# Altium schematic sheet 4  - Latching.SchDoc("4  - Latching")
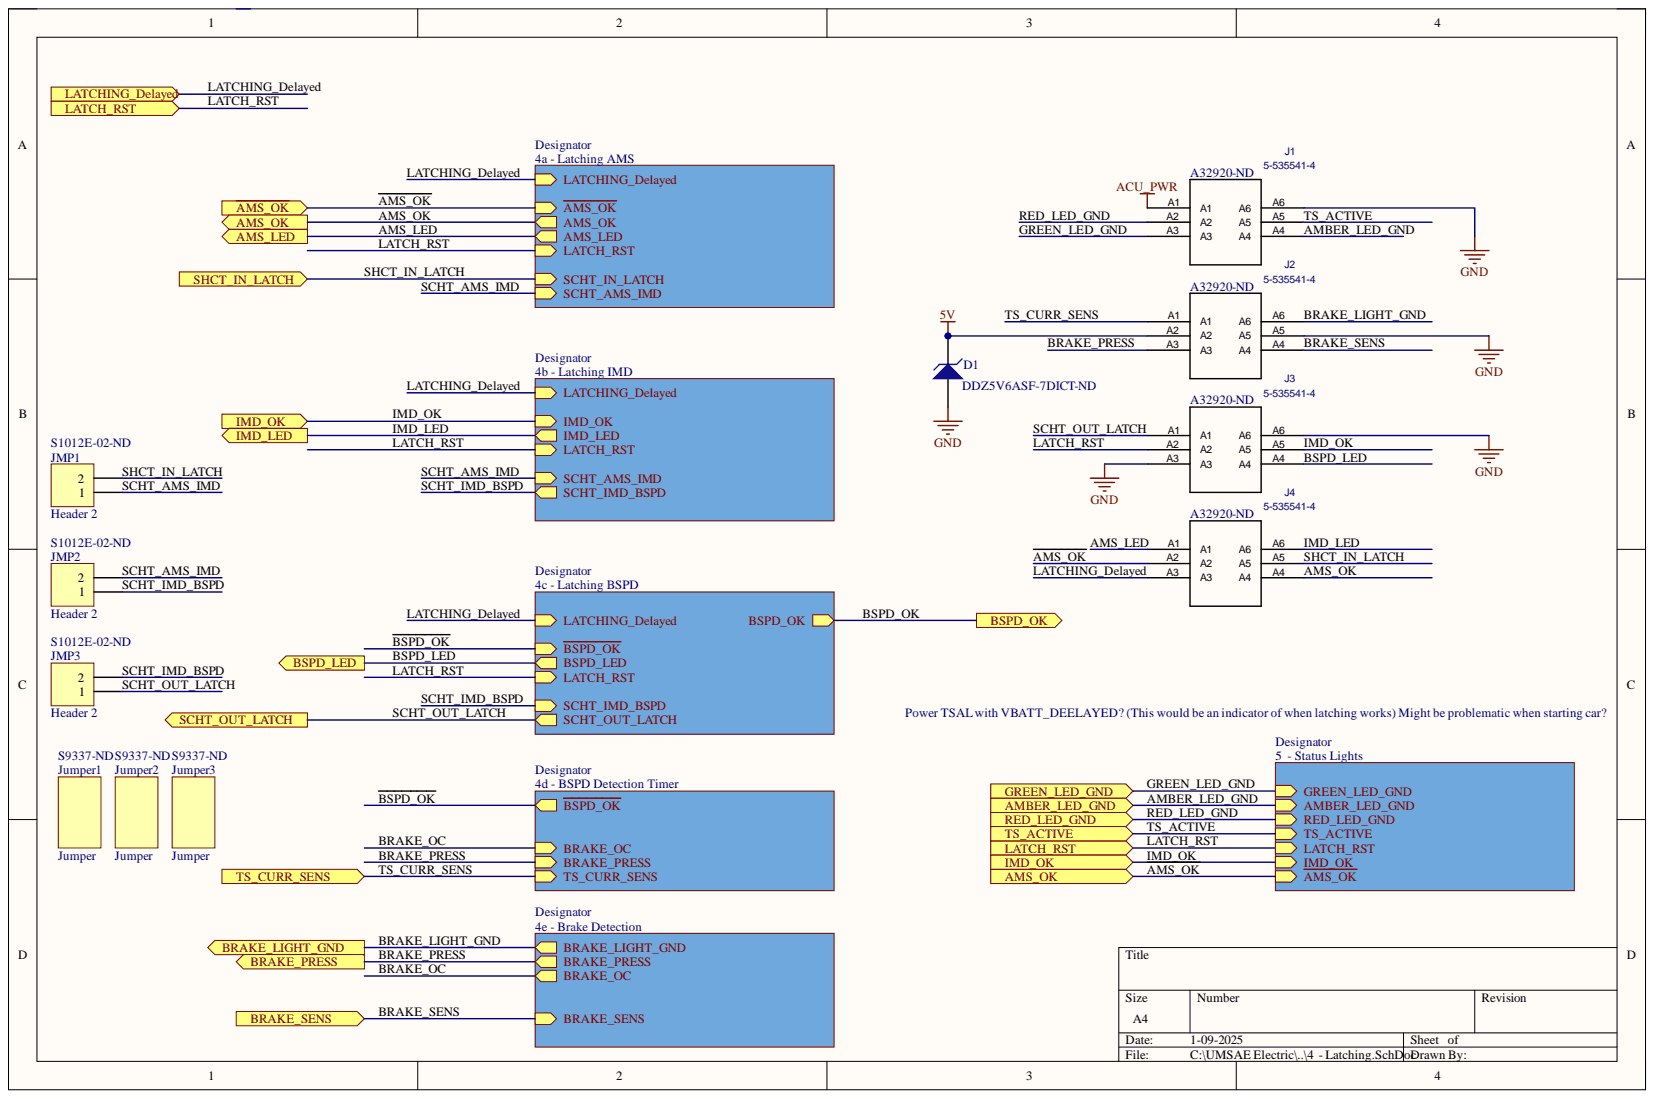
[diagram p0: 4  - Latching.SchDoc]

## Parts
D1  Supplier Part Number 1: DDZ5V6ASF-7DICT-ND  [DIODE_SOD323_101]  (hidden comment: D Zener)
J1  5-535541-4  Supplier Part Number 1: A32920-ND  [5-535541-4_5-535541-4(Primary)]
J2  5-535541-4  Supplier Part Number 1: A32920-ND  [5-535541-4_5-535541-4(Primary)]
J3  5-535541-4  Supplier Part Number 1: A32920-ND  [5-535541-4_5-535541-4(Primary)]
J4  5-535541-4  Supplier Part Number 1: A32920-ND  [5-535541-4_5-535541-4(Primary)]
JMP1  Header 2  Supplier Part Number 1: S1012E-02-ND  [2Pin Jumper]
JMP2  Header 2  Supplier Part Number 1: S1012E-02-ND  [2Pin Jumper]
JMP3  Header 2  Supplier Part Number 1: S1012E-02-ND  [2Pin Jumper]
Jumper1  Jumper  Supplier Part Number 1: S9337-ND
Jumper2  Jumper  Supplier Part Number 1: S9337-ND
Jumper3  Jumper  Supplier Part Number 1: S9337-ND

## Ports
AMBER_LED_GND, AMS_LED, AMS_OK, BRAKE_LIGHT_GND, BRAKE_PRESS, BRAKE_SENS, BSPD_LED, BSPD_OK, GREEN_LED_GND, IMD_LED, IMD_OK, LATCH_RST, LATCHING_Delayed, RED_LED_GND, SCHT_OUT_LATCH, SHCT_IN_LATCH, TS_ACTIVE, TS_CURR_SENS, ~{AMS_OK}

## Nets
5V: D1.2 J2.A2
ACU_PWR: J1.A1
GND: D1.1 J1.A6 J2.A5 J3.A3 J3.A6
~{AMS_OK}: J4.A2 ; port ~{AMS_OK}
AMBER_LED_GND: J1.A4 ; port AMBER_LED_GND
AMS_LED: J4.A1 ; port AMS_LED
AMS_OK: J4.A4 ; port AMS_OK
BRAKE_LIGHT_GND: J2.A6 ; port BRAKE_LIGHT_GND
BRAKE_PRESS: J2.A3 ; port BRAKE_PRESS
BRAKE_SENS: J2.A4 ; port BRAKE_SENS
BSPD_LED: J3.A4 ; port BSPD_LED
GREEN_LED_GND: J1.A3 ; port GREEN_LED_GND
IMD_LED: J4.A6 ; port IMD_LED
IMD_OK: J3.A5 ; port IMD_OK
LATCH_RST: J3.A2 ; port LATCH_RST
LATCHING_Delayed: J4.A3 ; port LATCHING_Delayed
RED_LED_GND: J1.A2 ; port RED_LED_GND
SCHT_AMS_IMD: JMP1.1 JMP2.2
SCHT_IMD_BSPD: JMP2.1 JMP3.2
SCHT_OUT_LATCH: J3.A1 JMP3.1 ; port SCHT_OUT_LATCH
SHCT_IN_LATCH: J4.A5 JMP1.2 ; port SHCT_IN_LATCH
TS_ACTIVE: J1.A5 ; port TS_ACTIVE
TS_CURR_SENS: J2.A1 ; port TS_CURR_SENS
~{BSPD_OK}:
BRAKE_OC:
BSPD_OK: ; port BSPD_OK

## Library data of the parts
D1 = D Zener: Zener Diode; Component Kind: Standard; LatestRevisionDate: 17-Jul-2002; LatestRevisionNote: Re-released for DXP Platform.; Library Name: Miscellaneous Devices.IntLib; PackageDescription: Diode, Thru-Hole; 2 Leads; 0.7 in Pin Spacing; PackageReference: DIODE-0.7; Published: 8-Jun-2000; Signal Integrity: D Zener; Simulation: ZENER; Supplier 1: Digi-Key; Publisher: Altium Limited
J1-J4 = 5-535541-4: Built_By: EMA_UL_Team; C: Copyright (C) 2015 Accelerated Designs. All rights reserved; Vendor: TE Connectivity; Component Kind: Standard; Manufacturer_Part_Number: 5-535541-4; Supplier 1: Digi-Key; Value: 5-535541-4; Library Name: ACB_lib.SchLib
JMP1-JMP3 = Header 2: Header, 2-Pin; Manufacturer: Harwin Inc.; Manufacturer Part Number: M20-9990246; Connector Type: Header, Cuttable; Contact Length - Mating: 0.240" (6.10mm); Contact Length - Post: 0.118" (3.00mm); Current Rating: 3A per Contact; Mated Stacking Heights: 10.04mm, 10.64mm, 11.04mm, 16.54mm; Material Flammability Rating: UL94 V-0; Number of Positions: 2; Number of Positions Loaded: All; Overall Contact Length: 0.460" (11.68mm); Pitch - Mating: 0.100" (2.54mm); Shrouding: Unshrouded; Style: Board to Board or Cable; Applications: -; Category: Connectors, Interconnects; Component Kind: Standard; ComponentLink1Description: https://cdn.harwin.com/pdfs/M20-999.pdf; ComponentLink1URL: https://cdn.harwin.com/pdfs/M20-999.pdf; Contact Finish - Mating: Tin; Contact Finish - Post: Tin; Contact Finish Thickness - Mating: -; Contact Finish Thickness - Post: -; Contact Material: Brass; Contact Shape: Square; Contact Type: Male Pin; Fastening Type: Push-Pull; Features: -; Ingress Protection: -; Insulation Color: Black; Insulation Height: 0.100" (2.54mm); Insulation Material: Polyamide (PA6T), Nylon 6T; LatestRevisionDate: 17-Jul-2002; LatestRevisionNote: Re-released for DXP Platform.; Library Name: Miscellaneous Connectors.IntLib; Mounting Type: Through Hole; Number of Rows: 1; Operating Temperature: -40°C ~ 105°C; Packaging: Bulk; Part Status: Active; RoHS: RoHS Compliant; Row Spacing - Mating: -; Signal Integrity: Connector; Supplier 1: Digi-Key; Termination: Solder; Voltage Rating: -; Publisher: Altium Limited
Jumper1-Jumper3 = Jumper: Component Kind: Standard; Supplier 1: Digi-Key; Library Name: BRAKE TEMP Components.SchLib
